# Altium schematic sheet PICSystem.SchDoc
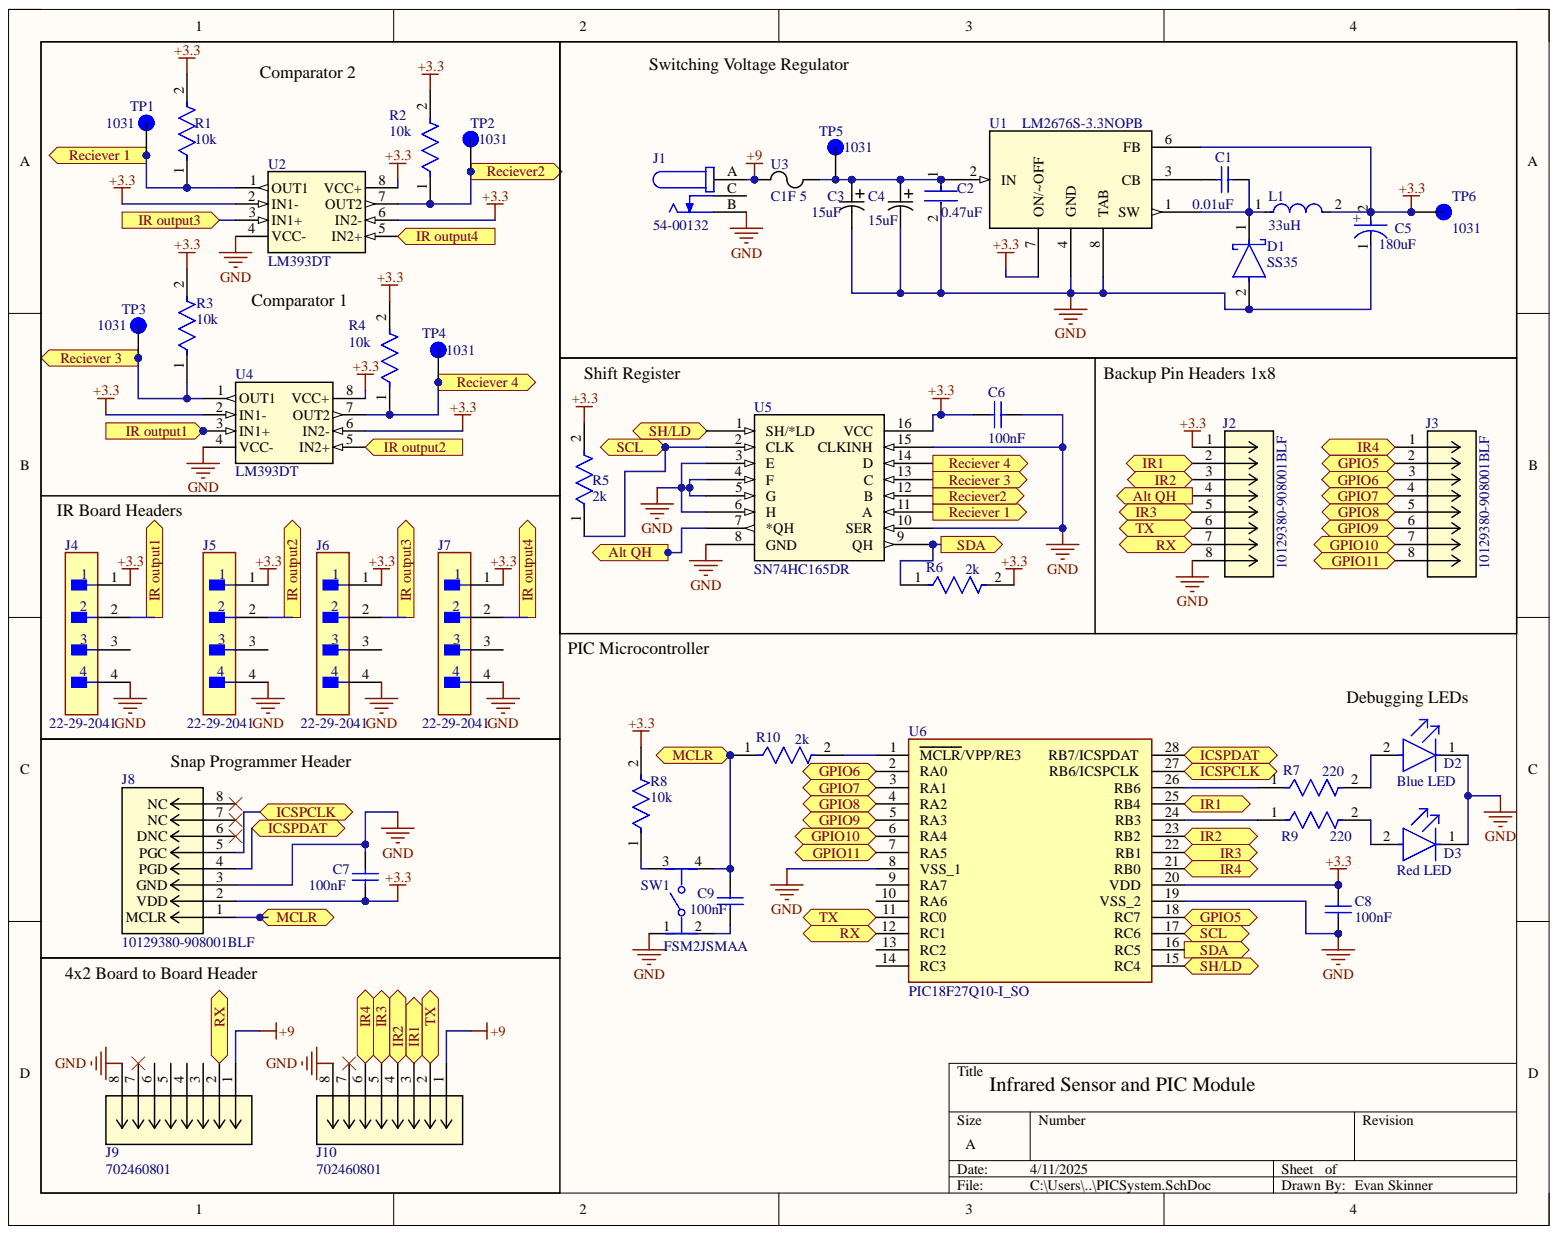
[diagram p0: PICSystem.SchDoc]

## Parts
C1  0.01uF  [CAPC2013X145X50ML20T25]
C2  0.47uF  [FP-CL21-IPC_A]
C3  15uF  [TACR_AVX]
C4  15uF  [TACR_AVX]
C5  180uF  [FP-SP-Cap-X-MFG]
C6  100nF  [CAPC2013X140X50LL20T25]
C7  100nF  [CAPC2013X140X50LL20T25]
C8  100nF  [CAPC2013X140X50LL20T25]
C9  100nF  [CAPC2013X140X50LL20T25]
D1  SS35  [FP-403AG-MFG]
D2  Blue LED  Color: Blue  [FP-6_541-5093_01-4-MFG]
D3  Red LED  Colour: Red  [FP-6_541-5092_01-4-MFG]
J1  54-00132  [TENSILITY_54-00132]
J2  10129380-908001BLF  [CONN_10129380-x08001xLF_AMP]
J3  10129380-908001BLF  [CONN_10129380-x08001xLF_AMP]
J4  22-29-2041  [FP-22-29-2041-MFG]
J5  22-29-2041  [FP-22-29-2041-MFG]
J6  22-29-2041  [FP-22-29-2041-MFG]
J7  22-29-2041  [FP-22-29-2041-MFG]
J8  10129380-908001BLF  [CONN_10129380-x08001xLF_AMP]
J9  702460801  [CONN_7024608xx_MOL]
J10  702460801  [CONN_7024608xx_MOL]
L1  33uH  [FP-LQH32CH_53-MFG]
R1  10k  [FP-CR0805-IPC_A]
R2  10k  [FP-CR0805-IPC_A]
R3  10k  [FP-CR0805-IPC_A]
R4  10k  [FP-CR0805-IPC_A]
R5  2k  [FP-CRCW0805-e3-IPC_B]
R6  2k  [FP-CRCW0805-e3-IPC_B]
R7  220  [FP-CRCW0805-e3-MFG]
R8  10k  [FP-CR0805-IPC_A]
R9  220  [FP-CRCW0805-e3-MFG]
R10  2k  [FP-CRCW0805-e3-IPC_B]
SW1  FSM2JSMAA  [FP-FSM2JSMAA-MFG]
TP1  1031  [KEYSTONE_1031]
TP2  1031  [KEYSTONE_1031]
TP3  1031  [KEYSTONE_1031]
TP4  1031  [KEYSTONE_1031]
TP5  1031  [KEYSTONE_1031]
TP6  1031  [KEYSTONE_1031]
U1  LM2676S-3.3NOPB  [TS7B]
U2  LM393DT  [SO8_STM]
U3  C1F 5  [C1_BEL]
U4  LM393DT  [SO8_STM]
U5  SN74HC165DR  [D16]
U6  PIC18F27Q10-I_SO  [SOIC127P1030X265-28N]

## Ports
Alt QH, GPIO5, GPIO6, GPIO7, GPIO8, GPIO9, GPIO10, GPIO11, ICSPCLK, ICSPDAT, IR1, IR2, IR3, IR4, IR output1, IR output2, IR output3, IR output4, MCLR, Reciever2, Reciever 1, Reciever 3, Reciever 4, RX, SCL, SDA, SH/LD, TX

## Nets
+3.3: C5.2 C6.1 C7.2 C8.1 J2.1 J4.1 J5.1 J6.1 J7.1 J8.2 L1.2 R1.2 R2.2 R3.2 R4.2 R5.2 R6.2 R8.2 TP6.TP U1.6(FB) U1.7(ON/~OFF) U2.2(IN1-) U2.6(IN2-) U2.8(VCC+) U4.2(IN1-) U4.6(IN2-) U4.8(VCC+) U5.16(VCC) U6.20(VDD)
+9: J1.A J9.1 J10.1 U3.1
GND: C2.2 C3.2 C4.2 C5.1 C6.2 C7.1 C8.2 D1.2 D2.1 D3.1 J1.B J2.8 J4.4 J5.4 J6.4 J7.4 J8.3 J9.8 J10.8 SW1.1 U1.4(GND) U1.8(TAB) U2.4(VCC-) U4.4(VCC-) U5.3(E) U5.4(F) U5.5(G) U5.6(H) U5.8(GND) U5.10(SER) U5.15(CLKINH) U6.8(VSS_1) U6.19(VSS_2)
Alt QH: J2.4 U5.7(*QH) ; port Alt QH
GPIO5: J3.2 U6.18(RC7) ; port GPIO5
GPIO6: J3.3 U6.2(RA0) ; port GPIO6
GPIO7: J3.4 U6.3(RA1) ; port GPIO7
GPIO8: J3.5 U6.4(RA2) ; port GPIO8
GPIO9: J3.6 U6.5(RA3) ; port GPIO9
GPIO10: J3.7 U6.6(RA4) ; port GPIO10
GPIO11: J3.8 U6.7(RA5) ; port GPIO11
ICSPCLK: J8.5 U6.27(RB6/ICSPCLK) ; port ICSPCLK
ICSPDAT: J8.4 U6.28(RB7/ICSPDAT) ; port ICSPDAT
IR1: J2.2 J10.3 U6.25(RB4) ; port IR1
IR2: J2.3 J10.4 U6.23(RB2) ; port IR2
IR3: J2.5 J10.5 U6.22(RB1) ; port IR3
IR4: J3.1 J10.6 U6.21(RB0) ; port IR4
IR output1: J4.2 U4.3(IN1+) ; port IR output1
IR output2: J5.2 U4.5(IN2+) ; port IR output2
IR output3: J6.2 U2.3(IN1+) ; port IR output3
IR output4: J7.2 U2.5(IN2+) ; port IR output4
MCLR: C9.1 J8.1 R10.1 SW1.4 ; port MCLR
Reciever2: R2.1 TP2.TP U2.7(OUT2) U5.12(B) ; port Reciever2
Reciever 1: R1.1 TP1.TP U2.1(OUT1) U5.11(A) ; port Reciever 1
Reciever 3: R3.1 TP3.TP U4.1(OUT1) U5.13(C) ; port Reciever 3
Reciever 4: R4.1 TP4.TP U4.7(OUT2) U5.14(D) ; port Reciever 4
RX: J2.7 J9.2 U6.12(RC1) ; port RX
SCL: R5.1 U5.2(CLK) U6.17(RC6) ; port SCL
SDA: R6.1 U5.9(QH) U6.16(RC5) ; port SDA
SH/LD: U5.1(SH/*LD) U6.15(RC4) ; port SH/LD
TX: J2.6 J10.2 U6.11(RC0) ; port TX
NetC1_1: C1.1 U1.3(CB)
NetC1_2: C1.2 D1.1 L1.1 U1.1(SW)
NetC2_1: C2.1 C3.1 C4.1 TP5.TP U1.2(IN) U3.2
NetC9_2: C9.2 SW1.2
NetD2_2: D2.2 R7.2
NetD3_2: D3.2 R9.2
NetR7_1: R7.1 U6.26(RB6)
NetR8_1: R8.1 SW1.3
NetR9_1: R9.1 U6.24(RB3)
NetR10_2: R10.2 U6.1(MCLR/VPP/RE3)

Not connected: J1 C; J4 3; J5 3; J6 3; J7 3; J8 6 7 8; J9 3 4 5 6 7; J10 7; U6 9(RA7) 10(RA6) 13(RC2) 14(RC3)

## Library data of the parts
C1 = CMP-2007-01059-1: Manufacturer: Kemet; Part Number: C0805W103KCRACTU; Component Kind: Standard; Library Name: Altium Content Vault
C2 = CMP-13271-002452-1: CL21 Series 0805 470nF 50V ±10% Tolerance X7R Multilayer Ceramic Chip Capacitor; Capacitance: 470nF; Case Code (Imperial): 0805; Case Code (Metric): 2012; Case/Package: 0805; Component Kind: Standard; Depth: 1.25mm; Dielectric: X7R; Height: 1.25mm; Lead Free: Lead Free; Length: 2mm; Library Name: Altium Content Vault; Max Operating Temperature: 125°C; Min Operating Temperature: -55°C; Mount: Surface Mount; Package Quantity: 1; Packaging: Tape and Reel; Radiation Hardening: No; Thickness: 0.053inch; Tolerance: 10%; Voltage: 50V; Voltage Rating: 50V; Voltage Rating (DC): 50V; Width: 0.049inch
C3 C4 = TACR156K010XTA: No Description Available; Mfr_Name: KYOCERA AVX; Component Kind: Standard; Library Name: TACR156K010XTA.SchLib
C5 = CMP-05428-000369-1: Molded Aluminum Polymer Capacitor 180uF 20% 2V life 2000Hours SMD 2917; Dielectric Material: Aluminium; Dissipation Factor: 0.06%; ESR (Equivalent Series Resistance): 9mR; Leakage Current: 36uA; Life (Hours): 1000Hour; Ripple Current: 3A; Capacitance: 180uF; Case Code (Imperial): 2917; Case Code (Metric): 7343; Case/Package: 2917; Component Kind: Standard; Construction: Flat; Depth: 4.3mm; Height: 1.9mm; Height - Seated (Max): 0.083inch; Length: 7.3mm; Library Name: Altium Content Vault; Max Operating Temperature: 105°C; Min Operating Temperature: -40°C; Mount: Surface Mount; Number of Pins: 2; Package Quantity: 3500; Packaging: Tape and Reel; Ripple Current (AC): 3A; RoHS Compliant: Yes; Series: SP-Cap SX; Termination: SMD/SMT; Tolerance: 20%; Voltage: 2V; Voltage Rating: 2V; Voltage Rating (DC): 2V; Width: 4.3mm
C6-C9 = CMP-2007-04740-1: Manufacturer: AVX Interconnect / Elco; Part Number: 08051C104M4Z2A; Component Kind: Standard; Library Name: Altium Content Vault
D1 = CMP-00278-84331626-1: SS35 Rectifier Diode, Schottky, 1 Phase, 1 Element, 3A, 50V V(RRM), Silicon, DO-214AB; Manufacturer Lifecycle Status: ACTIVE, NOT REC; Element Configuration: Single; Forward Voltage: 750mV; Max Forward Surge Current (Ifsm): 100A; Max Reverse Leakage Current: 500µA; Polarity: Standard; Average Rectified Current: 3A; Case/Package: SMC; Component Kind: Standard; Current Rating: 3A; Forward Current: 3A; Height: 2.75mm; Lead Free: Lead Free; Length: 7.15mm; Library Name: Altium Content Vault; Lifecycle Status: NRND; Max Operating Temperature: 150°C; Max Repetitive Reverse Voltage (Vrrm): 50V; Max Reverse Voltage (DC): 50V; Max Surge Current: 100A; Min Operating Temperature: -55°C; Mount: Surface Mount; NexarPartId: 84331626; Number of Pins: 2; Output Current: 3A; Packaging: Cut Tape; Peak Non-Repetitive Surge Current: 100A; Peak Reverse Current: 500µA; Radiation Hardening: No; REACH SVHC: No SVHC; RoHS: Compliant; Schedule B: 8541100080, 8541100080; Termination: SMD/SMT; Voltage Rating (DC): 50V; Weight: 300mg; Width: 6.25mm
D2 = CMP-00030-21709198-1: VLMB1310-GS08 LED Uni-Color Blue 468nm 2-Pin Chip 0603(1608Metric) T/R; Dominant Wavelength: 470nm; Forward Current: 20mA; Forward Voltage: 3.8V; Lens Style: Clear, Rectangular; Luminous Intensity: 180mcd; Number of LEDs: 1; Power Rating: 75mW; Viewing Angle: 130°; Wavelength: 475nm; Case Code (Imperial): 0603; Case Code (Metric): 1608; Case/Package: 0603; Component Kind: Standard; Height: 800µm; Illumination Color: Blue; Lead Free: Lead Free; Length: 1.6mm; Library Name: Altium Content Vault; Max Operating Temperature: 85°C; Min Operating Temperature: -55°C; Mount: Surface Mount; NexarPartId: 21709198; Number of Pins: 2; Packaging: Tape & Reel; Radiation Hardening: No; REACH SVHC: Unknown; RoHS: Compliant; Schedule B: 8541402000, 8541402000; Supplier: Mouser; Supplier Part Number: 579-PIC18F27Q10TIML; Test Current: 20mA; Width: 800µm
D3 = CMP-02520-000012-1: LED RED 0603 SMD; Manufacturer: Vishay; Manufacturer Part Number: VLMS1300-GS08; Forward Current: 20mA; Forward Voltage: 2V; Led Orientation: Top View; Luminous Intensity: 54mcd; Peak Emmision Wavelength: 639nm; Power Dissipation: 75mW; Reverse Voltage: 5V; Viewing Angle: 130°; Wavelength: 631nm; Ambient Temperature Range High: +85°C; Ambient Temperature Range Low: -35°C; Automotive: No; Category: Diode; Component Kind: Standard; ComponentLink2Description: Datasheet; ComponentLink2URL: http://www.vishay.com/docs/82437/vlmo1300.pdf; Device Class L1: Optoelectronics; Device Class L2: LEDs; Device Class L3: unset; Digikey Description: LED RED 0603 SMD; Height: 1mm; Lead Free: Yes; Library Name: Altium Content Vault; Mouser Description: Standard LEDs - SMD Super Red Clear Non-Diff; Octopart: https://octopart.com/vlms1300-gs08-vishay-21709201; Package: 6.541-5092.01-4; RoHS: TRUE; Temperature: -35°C to +85°C; Temperature Range High: +85°C; Temperature Range Low: -35°C
J1 = 54-00132: Connector, dc jack 5.5x2.5 mm, PCB mount, 90 degrees, silver plated, board lock; MF: Tensility International Corp; Availability: In Stock; Check_prices: https://www.snapeda.com/parts/54-00132/Tensility+International+Corp/view-part/?ref=eda; Component Kind: Standard; Library Name: 54-00132.IntLib; MP: 54-00132; Package: None; Price: None; Purchase-URL: https://pricing.snapeda.com/search?q=54-00132&ref=eda; SnapEDA_Link: https://www.snapeda.com/parts/54-00132/Tensility+International+Corp/view-part/?ref=snap
J2 J3 J8 = 10129380-908001BLF: No Description Available; Mfr_Name: Amphenol ICC; Component Kind: Standard; RefDes: RefDes; Type: Headers, Male Pins
  J2 J3: Library Name: 10129380-908001BLF.IntLib
  J8: ComponentLink1Description: New link; Library Name: 10129380-908001BLF.SchLib
J4-J7 = CMP-04776-000366-1: CONN HEADER VERT 4POS 2.54MM; Contact Resistance: 10mR; Current Rating: 4A; Gender: Male; Glow Wire Compliant: Non-compliant; Insulation Resistance: 50GR; Number of Circuits: 4; Number of Lines: 1; Voltage Rating: 250V; Color: Natural; Component Kind: Standard; Contact Gender: Male; Contact Material: Brass; Contact Mating Length: 0.295inch; Contact Plating: Gold; Contact Type: Male Pin; Depth: 5.8mm; Fastening Type: Friction; Flammability Rating: UL94 V-0; Halogen Free: Low Halogen; Height: 11.7mm; Housing Color: White; Housing Material: Nylon; Lead Free: Lead Free; Length: 10.16mm; Library Name: Altium Content Vault; Max Current Rating: 4A; Max Operating Temperature: 75°C; Min Operating Temperature: 0°C; Mount: Through Hole; Number of Contacts: 4; Number of Poles: 4; Number of Positions: 4; Number of Rows: 1; Orientation: Straight; Package Quantity: 1500; Packaging: Bulk; Pitch: 2.54mm; Radiation Hardening: No; RoHS Compliant: Yes; Series: KK® 6410; Termination: Solder; Voltage Rating (DC): 250V
J9 J10 = 702460801: No Description Available; Mfr_Name: Molex Connector Corporation; Component Kind: Standard; Library Name: 702460801.SchLib; RefDes: RefDes; Type: Type
L1 = CMP-06042-002142-1: Wire Wound Ferrite Inductor for Power Lines 33μH ±10% 1.43Ω 200mA 1210; DC Resistance (DCR): 1.1R; Self Resonant Frequency: 17MHz; Shielding: Unshielded; Test Frequency: 1MHz; Case Code (Imperial): 1210; Case Code (Metric): 3225; Case/Package: 1210; Component Kind: Standard; Core Material: Ferrite; Current Rating: 200mA; DC Current: 200mA; Depth: 2.8mm; Height: 2mm; Height - Seated (Max): 0.067inch; Inductance: 33uH; Lead Free: Lead Free; Length: 3.2mm; Library Name: Altium Content Vault; Max DC Current: 200mA; Max Operating Temperature: 85°C; Military Standard: Not; Min Operating Temperature: -25°C; Mount: Surface Mount; Package Quantity: 2000; Packaging: Tape and Reel; Radiation Hardening: No; Resistor Type: Wirewound; Termination: SMD/SMT; Tolerance: 10%; Weight: 0.002116oz; Width: 2.5mm
R1-R4 R8 = CMP-07231-003204-1: Thick Film Chip Resistors 0805 10kΩ 0.125W 1% 100ppm/°C; Max Power Dissipation: 125mW; Resistance: 10kR; Voltage Rating: 150V; Voltage Rating (DC): 100V; Case Code (Imperial): 0805; Case Code (Metric): 2012; Case/Package: 0805; Component Kind: Standard; Composition: Thick Film; Contact Plating: Tin; Depth: 1.25mm; Features: Moisture Resistant; Height: 0.5mm; Length: 2mm; Library Name: Altium Content Vault; Max Operating Temperature: 155°C; Min Operating Temperature: -55°C; Mount: Surface Mount; Number of Terminations: 2; Package Quantity: 1; Packaging: Tape and Reel; Power Rating: 125mW; Radiation Hardening: No; REACH SVHC: No SVHC; Temperature Coefficient: 100ppm/°C; Termination: SMD/SMT; Thickness: 508um; Tolerance: 1%; Width: 1.25mm
R5 R6 R10 = CMP-2001-03755-2: RES Thick Film, 2kΩ, 1%, 0.125W, 100ppm/°C, 0805; Max Power Dissipation: 125mW; Resistance: 2kR; Voltage Rating: 150V; Case Code (Imperial): 0805; Case Code (Metric): 2012; Case/Package: 0805; Component Kind: Standard; Composition: Thick Film; Contact Plating: Tin; Depth: 1.25mm; Features: Automotive AEC-Q200; Height: 0.02inch; Lead Free: Lead Free; Length: 2mm; Library Name: Altium Content Vault; Max Operating Temperature: 155°C; Military Standard: Not; Min Operating Temperature: -55°C; Mount: Surface Mount; Number of Pins: 2; Number of Terminals: 2; Number of Terminations: 2; Package Quantity: 10; Packaging: Tape and Reel; Power Rating: 125mW; Radiation Hardening: No; Temperature Coefficient: 100ppm/°C; Termination: SMD/SMT; Tolerance: 1%; Voltage Rating (DC): 150V; Weight: 0.000194oz; Width: 1.25mm; Working Voltage: 150V
R7 R9 = CMP-2001-03682-2: RES Thick Film, 220Ω, 1%, 0.125W, 100ppm/°C, 0805; Max Power Dissipation: 125mW; Resistance: 220R; Voltage Rating: 150V; Case Code (Imperial): 0805; Case Code (Metric): 2012; Case/Package: 0805; Component Kind: Standard; Composition: Thick Film; Contact Plating: Tin; Depth: 1.25mm; Features: Automotive AEC-Q200; Height: 0.45mm; Lead Free: Lead Free; Length: 2mm; Library Name: Altium Content Vault; Max Operating Temperature: 155°C; Military Standard: Not; Min Operating Temperature: -55°C; Mount: Surface Mount; Number of Terminations: 2; Package Quantity: 10; Packaging: Tape and Reel; Power Rating: 125mW; Radiation Hardening: No; Temperature Coefficient: 100ppm/°C; Termination: SMD/SMT; Tolerance: 1%; Voltage Rating (DC): 150V; Weight: 0.000194oz; Width: 0.049inch
SW1 = CMP-03402-000003-1: SWITCH TACTILE SPST-NO 0.05A 24V; Manufacturer: TE Connectivity; Contact Current Rating: 50mA; Contact Resistance: 100mΩ; Electromechanical Life: 100000Cycles; Operating Force: 260gf; Standoff Height: 0.05mm; Automotive: No; Category: Switch; Component Kind: Standard; ComponentLink2Description: Datasheet; ComponentLink2URL: https://www.te.com/commerce/DocumentDelivery/DDEController?Action=showdoc&DocId=Customer+Drawing%7F2-1437565-7%7FV%7Fpdf%7FEnglish%7FENG_CD_2-1437565-7_V.pdf%7F2-1437565-8; Device Class L1: Electromechanical; Device Class L2: Switches; Device Class L3: Pushbutton Switches; Digikey Description: SWITCH TACTILE SPST-NO 0.05A 24V; Height: 4.59mm; Lead Free: Yes; Library Name: Altium Content Vault; Manufacturer Part Number: FSM2JSMAA; Mount: Surface Mount; Mouser Description: Tactile Switches TACTILE 260G FORCE S; Octopart: https://octopart.com/fsm2jsmaa-te+connectivity-41720620; Package: 0; RoHS: TRUE; Temperature: -35°C to +85°C; Temperature Range High: +85°C; Temperature Range Low: -35°C; Throw Configuration: SPST-NO; Voltage Rating Dc: 24V
U1 = LM2676S-3.3NOPB: No Description Available; Mfr_Name: Texas Instruments; Component Kind: Standard; Library Name: LM2676S-3P3NOPB.SchLib; RefDes: RefDes; Type: LM2676S-12
U2 U4 = LM393DT: No Description Available; Mfr_Name: STMicroelectronics; Component Kind: Standard; Library Name: LM393DT.SchLib; RefDes: RefDes; Type: Type
U3 = C1F 5: No Description Available; Mfr_Name: Belfuse; Component Kind: Standard; Library Name: C1F5.SchLib
U5 = SN74HC165DR: No Description Available; Mfr_Name: Texas Instruments; Component Kind: Standard; Library Name: SN74HC165DR.SchLib; RefDes: RefDes; Type: Type
U6 = PIC18F27Q10-I_SO: Integrated Circuit; Manufacturer_Name: Microchip; Manufacturer_Part_Number: PIC18F27Q10-I/SO; Arrow Part Number: PIC18F27Q10-I/SO; Arrow Price/Stock: https://www.arrow.com/en/products/pic18f27q10-iso/microchip-technology?utm_currency=USD&region=nac; Component Kind: Standard; Datasheet Link: https://www.microchip.com/wwwproducts/en/PIC18F27Q10; Height: 2.65mm; Mouser Part Number: 579-PIC18F27Q10-I/SO; Mouser Price/Stock: https://www.mouser.co.uk/ProductDetail/Microchip-Technology/PIC18F27Q10-I-SO?qs=y6ZabgHbY%252Bz2dn6AlwCP3g%3D%3D
TP1-TP6 = 1031: Availability: Unavailable; Component Kind: Standard; Library Name: 1031.IntLib; MP: 1031; Package: None; Price: None
